# Altium schematic sheet SWD.SchDoc(U_SWD)
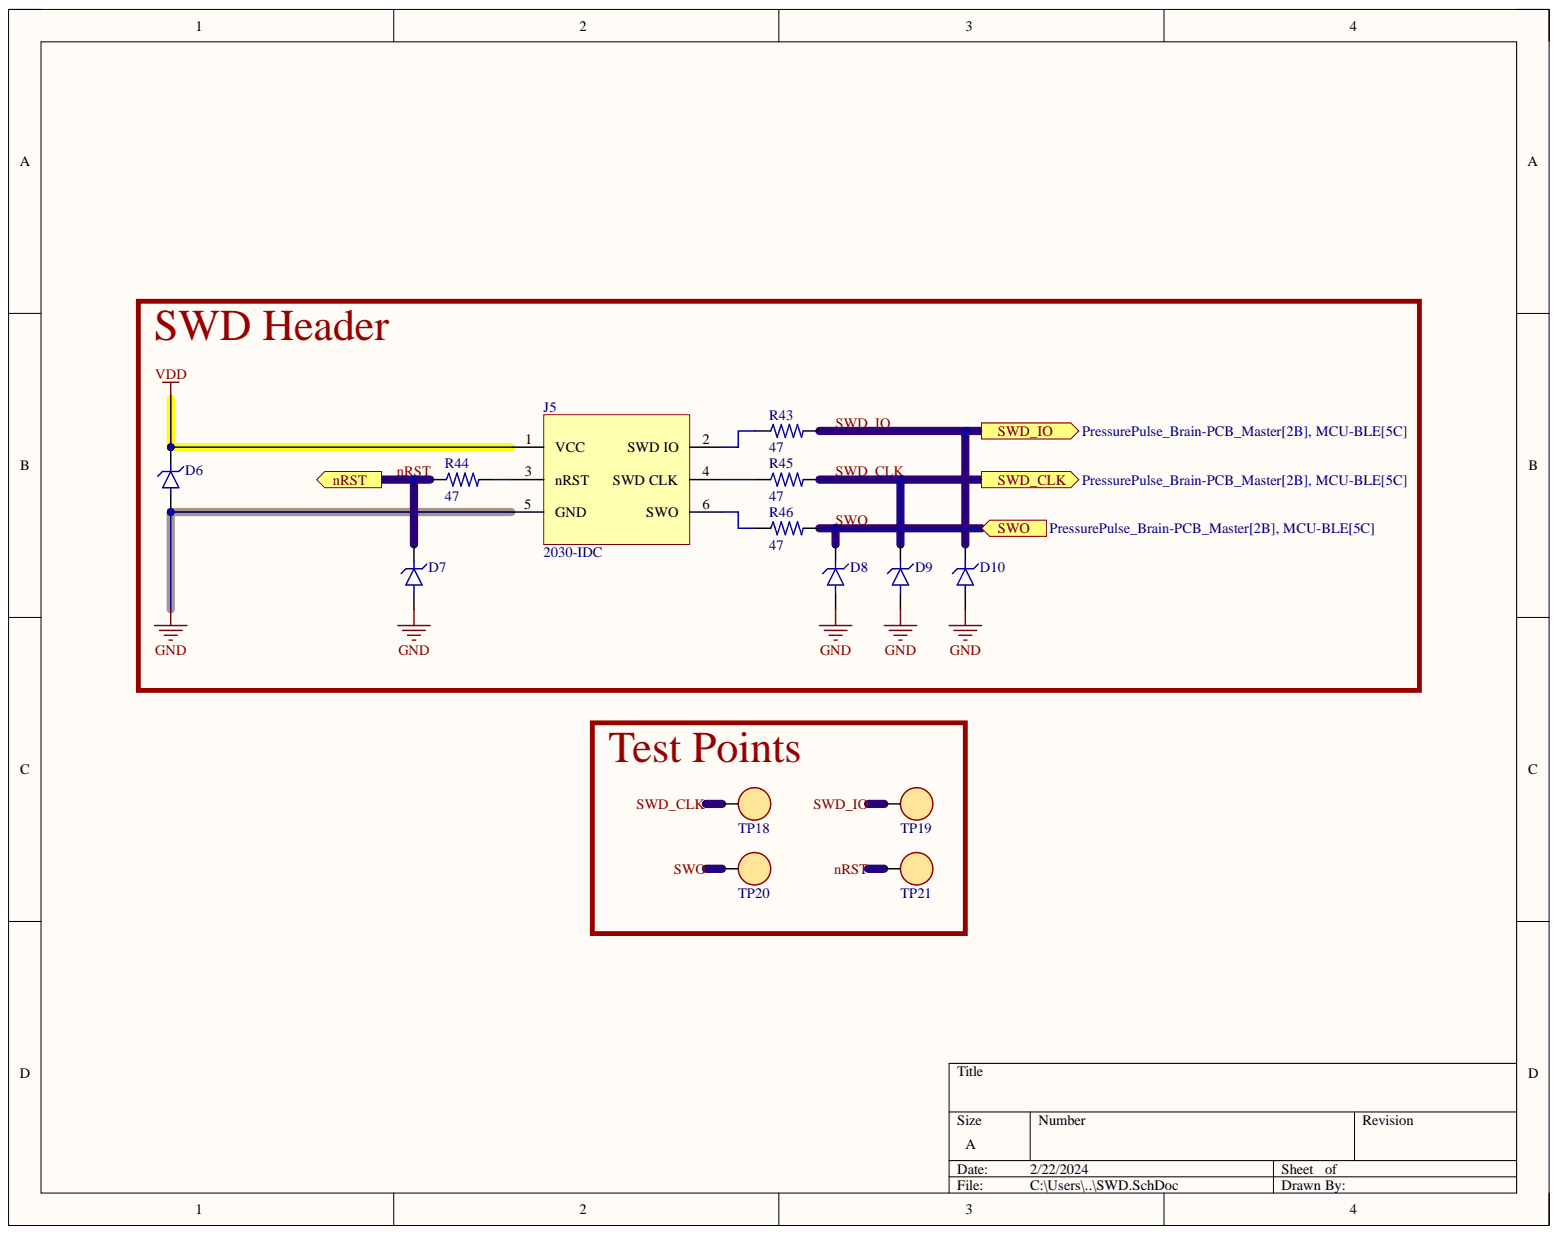
[diagram p0: SWD.SchDoc]

## Parts
D6  RCLAMP0521P.TCT  [RCLAMP0521P.TCT]  (value not drawn)
D7  RCLAMP0521P.TCT  [RCLAMP0521P.TCT]  (value not drawn)
D8  RCLAMP0521P.TCT  [RCLAMP0521P.TCT]  (value not drawn)
D9  RCLAMP0521P.TCT  [RCLAMP0521P.TCT]  (value not drawn)
D10  RCLAMP0521P.TCT  [RCLAMP0521P.TCT]  (value not drawn)
J5  2030-IDC  [TAG CONNECT TC2030IDC - NO 3D MODEL]
R43  value 47  (hidden comment: RES)
R44  value 47  (hidden comment: RES)
R45  value 47  (hidden comment: RES)
R46  value 47  (hidden comment: RES)
TP18  RH-5019  [TP - RH-5019]  (value not drawn)
TP19  RH-5019  [TP - RH-5019]  (value not drawn)
TP20  RH-5019  [TP - RH-5019]  (value not drawn)
TP21  RH-5019  [TP - RH-5019]  (value not drawn)

## Ports
nRST, SWD_CLK, SWD_IO, SWO

## Nets
GND: D6.A D7.A D8.A D9.A D10.A J5.5(GND)
VDD: D6.K J5.1(VCC)
nRST: D7.K R44.1 TP21.1 ; port nRST
SWD_CLK: D9.K R45.2 TP18.1 ; port SWD_CLK
SWD_IO: D10.K R43.2 TP19.1 ; port SWD_IO
SWO: D8.K R46.2 TP20.1 ; port SWO
NetJ5_2: J5.2(SWD_IO) R43.1
NetJ5_3: J5.3(nRST) R44.2
NetJ5_4: J5.4(SWD_CLK) R45.1
NetJ5_6: J5.6(SWO) R46.1

## Library data of the parts
D6-D10 = TVS DIODE: 25V Clamp 4A (8/20µs) Ipp Tvs Diode Surface Mount SLP1006P2; MFR: Semtech Corporation; Type: SMD; Component Kind: Standard; Library Name: PressurePulse.SchLib; MFR PN: RCLAMP0521P.TCT; Value: RCLAMP0521P.TCT
J5 = TAG CONNECT 2030-IDC: MFR PN: TC2030-IDC; Value: DNS; Component Kind: Standard; Description: TAG CONNECT 2030-IDC; Library Name: PressurePulse.SchLib; MFR: Tag Connect
R43-R46 = RES: Resistor; Component Kind: Standard; Library Name: PressurePulse.SchLib
TP18-TP21 = TEST POINT: MFR: ronghe; Component Kind: Standard; JLCPCB PN: C5199800; Library Name: PressurePulse.SchLib; MFR PN: RH-5019; Value: RH-5019
